# Altium schematic sheet ESP32.SchDoc(ESP32)
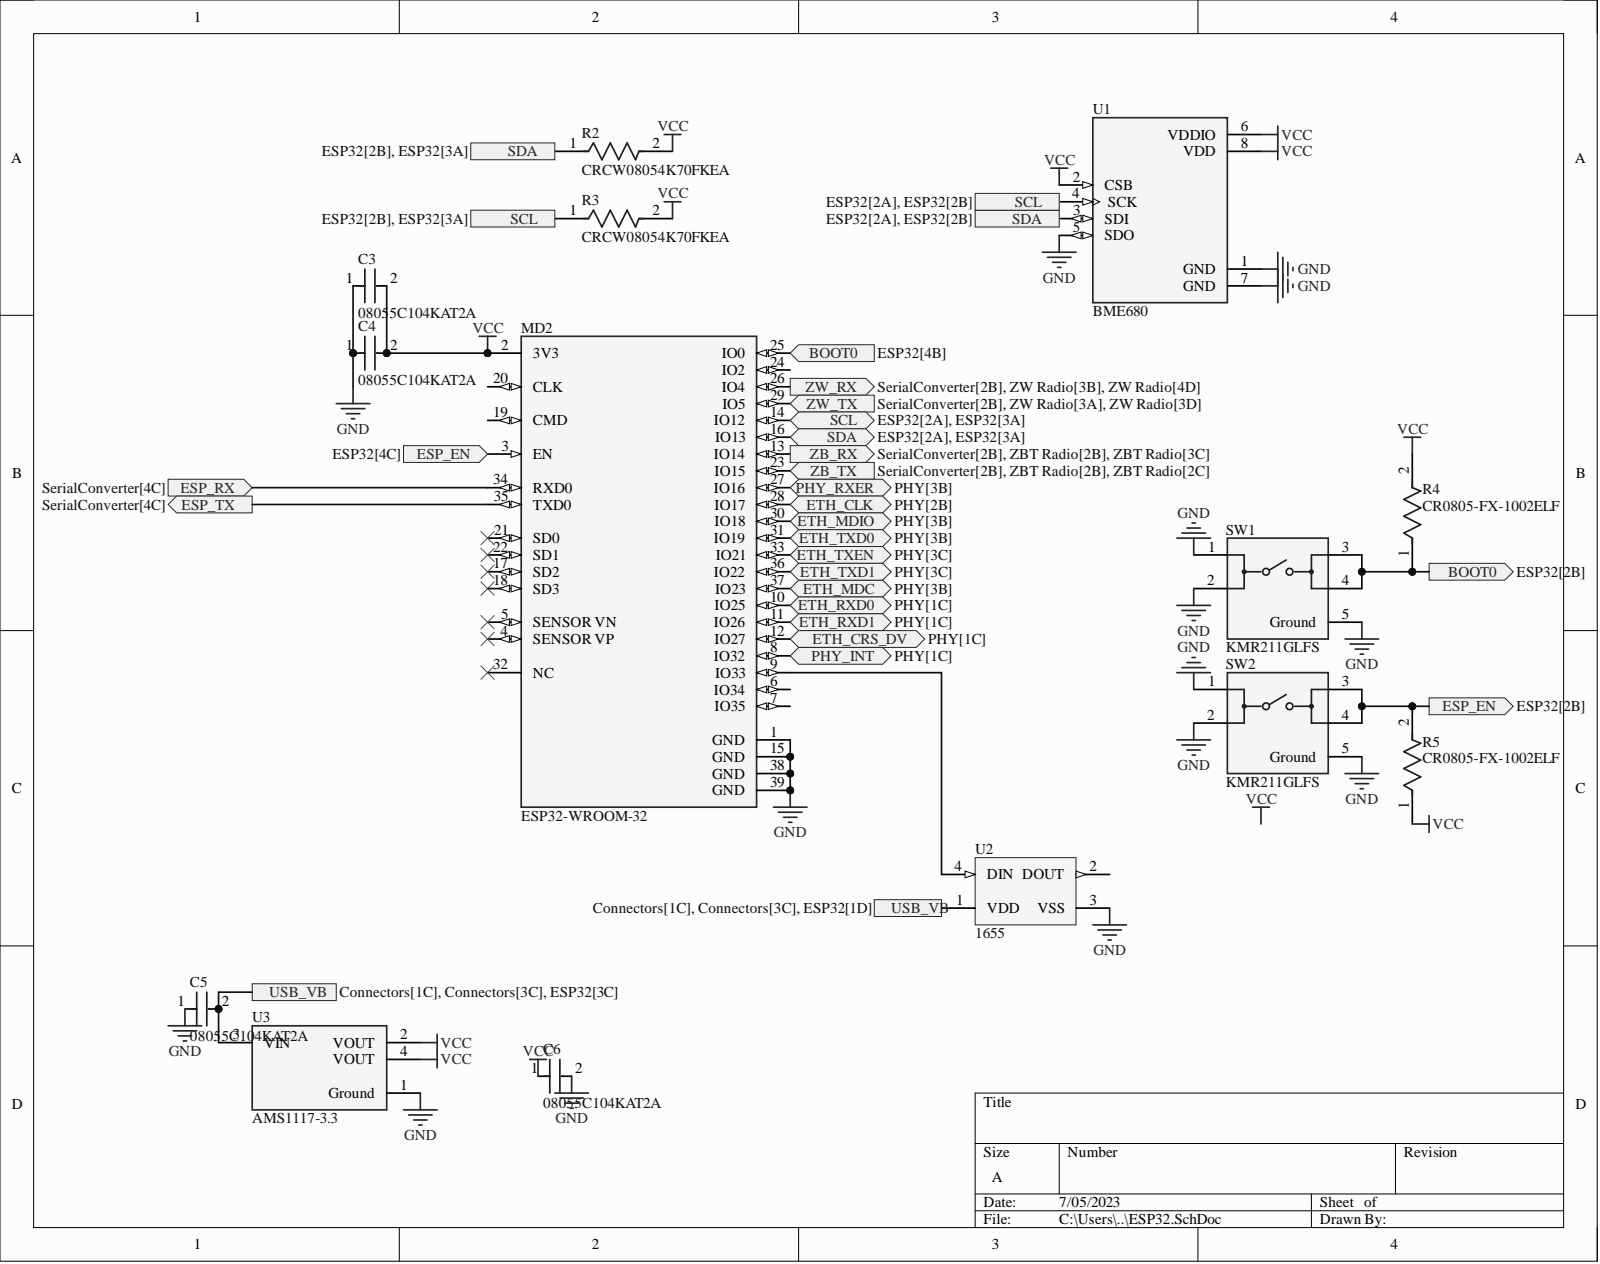
[diagram p0: ESP32.SchDoc]

## Parts
C3  08055C104KAT2A  [FP-0805-L_2_01_0_2-W_1_25-IPC_C]
C4  08055C104KAT2A  [FP-0805-L_2_01_0_2-W_1_25-IPC_C]
C5  08055C104KAT2A  [FP-0805-L_2_01_0_2-W_1_25-IPC_C]
C6  08055C104KAT2A  [FP-0805-L_2_01_0_2-W_1_25-IPC_C]
MD2  ESP32-WROOM-32  [FP-ESP32-WROOM-32-MFG]
R2  CRCW08054K70FKEA  [FP-CRCW0805-e3-IPC_B]
R3  CRCW08054K70FKEA  [FP-CRCW0805-e3-IPC_B]
R4  CR0805-FX-1002ELF  [FP-CR0805-IPC_A]
R5  CR0805-FX-1002ELF  [FP-CR0805-IPC_A]
SW1  KMR211GLFS  [FP-KMR211GLFS-MFG]
SW2  KMR211GLFS  [FP-KMR211GLFS-MFG]
U1  BME680  [PSON80P300X300X100-8N]
U2  1655  [ADA-RGB-1655_V]
U3  AMS1117-3.3  [FP-AMS1117-IPC_C]

## Ports
BOOT0, ESP_EN, ESP_RX, ESP_TX, ETH_CLK, ETH_CRS_DV, ETH_MDC, ETH_MDIO, ETH_RXD0, ETH_RXD1, ETH_TXD0, ETH_TXD1, ETH_TXEN, PHY_INT, PHY_RXER, SCL, SDA, USB_VB, ZB_RX, ZB_TX, ZW_RX, ZW_TX

## Nets
GND: C3.1 C4.1 C5.1 C6.2 MD2.1(GND) MD2.15(GND) MD2.38(GND) MD2.39(GND) SW1.1 SW1.2 SW1.5(Ground) SW2.1 SW2.2 SW2.5(Ground) U1.1(GND) U1.5(SDO) U1.7(GND) U2.3(VSS) U3.1(Ground)
VCC: C3.2 C4.2 C6.1 MD2.2(3V3) R2.2 R3.2 R4.2 R5.1 U1.2(CSB) U1.6(VDDIO) U1.8(VDD) U3.2(VOUT) U3.4(VOUT)
BOOT0: MD2.25(IO0) R4.1 SW1.3 SW1.4 ; port BOOT0
ESP_EN: MD2.3(EN) R5.2 SW2.3 SW2.4 ; port ESP_EN
ESP_RX: MD2.34(RXD0) ; port ESP_RX
ESP_TX: MD2.35(TXD0) ; port ESP_TX
ETH_CLK: MD2.28(IO17) ; port ETH_CLK
ETH_CRS_DV: MD2.12(IO27) ; port ETH_CRS_DV
ETH_MDC: MD2.37(IO23) ; port ETH_MDC
ETH_MDIO: MD2.30(IO18) ; port ETH_MDIO
ETH_RXD0: MD2.10(IO25) ; port ETH_RXD0
ETH_RXD1: MD2.11(IO26) ; port ETH_RXD1
ETH_TXD0: MD2.31(IO19) ; port ETH_TXD0
ETH_TXD1: MD2.36(IO22) ; port ETH_TXD1
ETH_TXEN: MD2.33(IO21) ; port ETH_TXEN
PHY_INT: MD2.8(IO32) ; port PHY_INT
PHY_RXER: MD2.27(IO16) ; port PHY_RXER
SCL: MD2.14(IO12) R3.1 U1.4(SCK) ; port SCL
SDA: MD2.16(IO13) R2.1 U1.3(SDI) ; port SDA
USB_VB: C5.2 U2.1(VDD) U3.3(VIN) ; port USB_VB
ZB_RX: MD2.13(IO14) ; port ZB_RX
ZB_TX: MD2.23(IO15) ; port ZB_TX
ZW_RX: MD2.26(IO4) ; port ZW_RX
ZW_TX: MD2.29(IO5) ; port ZW_TX
NetMD2_9: MD2.9(IO33) U2.4(DIN)

Not connected: MD2 4(SENSOR_VP) 5(SENSOR_VN) 6(IO34) 7(IO35) 17(SD2) 18(SD3) 19(CMD) 20(CLK) 21(SD0) 22(SD1) 24(IO2) 32(NC); U2 2(DOUT)

## Library data of the parts
C3-C6 = CMP-2000-05016-2: General Purpose Ceramic Capacitor, 0805, 100nF, 10%, X7R, 0.15, 50V; Dissipation Factor: 2.5%; Insulation Resistance: 100GR; Capacitance: 100nF; Case Code (Imperial): 0805; Case Code (Metric): 2012; Case/Package: 0805; Component Kind: Standard; Depth: 1.25mm; Dielectric: X7R; Dielectric Material: Ceramic; Height: 0.5mm; Lead Free: Lead Free; Length: 2mm; Library Name: Altium Content Vault; Max Operating Temperature: 125°C; Min Operating Temperature: -55°C; Mount: Surface Mount; Number of Pins: 2; Package Quantity: 4000; Packaging: Cut Tape; Radiation Hardening: No; REACH SVHC: No SVHC; RoHS Compliant: Yes; Series: MLCC X7R; Termination: Standard; Thickness: 0.037inch; Tolerance: 10%; Voltage: 50V; Voltage Rating: 50V; Voltage Rating (DC): 50V; Weight: 0.001411oz; Width: 1.2mm
MD2 = CMP-194065-000008-1: RX TXRX MOD WIFI SURFACE MOUNT; Data Rate: 150Mbps; Frequency: 2.5GHz; Operating Supply Voltage: 3.6V; Sensitivity: -98dBm; Case/Package: SMD/SMT; Component Kind: Standard; Library Name: Altium Content Vault; Max Operating Temperature: 85°C; Min Operating Temperature: -40°C; Mount: Surface Mount; Package Quantity: 550; Packaging: Cut Tape; Weight: 0.088185oz
R2 R3 = CMP-2100-03615-2: RES Thick Film, 4.7kΩ, 1%, 0.125W, 100ppm/°C, 0805; Max Power Dissipation: 125mW; Resistance: 4.7kR; Voltage Rating: 150V; Case Code (Imperial): 0805; Case Code (Metric): 2012; Case/Package: 0805; Component Kind: Standard; Composition: Thick Film; Contact Plating: Tin; Depth: 1.25mm; Features: Automotive AEC-Q200; Height: 0.45mm; Lead Free: Lead Free; Length: 2mm; Library Name: Altium Content Vault; Max Operating Temperature: 155°C; Military Standard: Not; Min Operating Temperature: -55°C; Number of Terminations: 2; Package Quantity: 10; Packaging: Tape and Reel; Power Rating: 125mW; Radiation Hardening: No; Temperature Coefficient: 100ppm/°C; Termination: SMD/SMT; Tolerance: 1%; Voltage Rating (DC): 150V; Weight: 0.000194oz; Width: 1.25mm
R4 R5 = CMP-07231-003204-1: Thick Film Chip Resistors 0805 10kΩ 0.125W 1% 100ppm/°C; Max Power Dissipation: 125mW; Resistance: 10kR; Voltage Rating: 150V; Voltage Rating (DC): 100V; Case Code (Imperial): 0805; Case Code (Metric): 2012; Case/Package: 0805; Component Kind: Standard; Composition: Thick Film; Contact Plating: Tin; Depth: 1.25mm; Features: Moisture Resistant; Height: 0.5mm; Length: 2mm; Library Name: Altium Content Vault; Max Operating Temperature: 155°C; Min Operating Temperature: -55°C; Mount: Surface Mount; Number of Terminations: 2; Package Quantity: 1; Packaging: Tape and Reel; Power Rating: 125mW; Radiation Hardening: No; REACH SVHC: No SVHC; Temperature Coefficient: 100ppm/°C; Termination: SMD/SMT; Thickness: 508um; Tolerance: 1%; Width: 1.25mm
SW1 SW2 = CMP-10040-000023-1: SWITCH TACTILE SPST-NO 0.05A 32V; Actuator Length: 0.5mm; Actuator Type: Standard; Contact Current Rating: 50mA; Illumination Type: Non-Illuminated; Mechanical Life: 200000Cycles; Operating Force: 1.2N; Power Rating: 1W; Switch Function: Off-Mom; Switch Travel: 0.2mm; Throw Configuration: SPST; Component Kind: Standard; Contact Material: Silver; Contact Plating: Silver; Current Rating: 50mA; Depth: 4.6mm; Lead Free: Lead Free; Length: 2.8mm; Library Name: Altium Content Vault; Max Current Rating: 50mA; Max Operating Temperature: 85°C; Max Voltage Rating (DC): 32V; Min Operating Temperature: -40°C; Mount: Surface Mount; Orientation: Straight; Package Quantity: 1; Packaging: Tape and Reel; Radiation Hardening: No; REACH SVHC: No SVHC; Termination: Gull Wing; Voltage Rating (DC): 32V; Width: 2.8mm
U1 = BME680: Integrated Environmental Unit; MF: {'manufacturer': u'Bosch Sensortec'}; Availability: Unavailable; Component Kind: Standard; MP: BME680; Package: None; Price: None
U2 = CMP-2000-05026-1: Intelligent Control LED Integrated Light Source, 3.5 to 5.3 V, -25 to 80 degC, 4-Pin SMD, RoHS, Tape and Reel; Manufacturer: Adafruit-Industries-LLC; Configuration: Discrete; Number of LEDs: 10; Utilized LED: WS2812(5050); Category: Optoelectronics; Color: Red,Green,Blue(RGB); Component Kind: Standard; Controller Type: -; Datasheet URL: http://datasheet.octopart.com/ADA1655-Adafruit-Industries-datasheet-32028139.pdf; Datasheet Version: May-13; Family: Addressable,Specialty; Interface: -; Library Name: Altium Content Vault; Manufacturer URL: https://www.adafruit.com/; Mounting Type: SurfaceMount; Package Description: 4-Pin Surface Mount Device, Body 5 x 5 mm; Package Reference: 1655; Series: Neopixel; Size / Dimension: 5.00mmLx5.00mmW
U3 = CMP-209535-000001-1: LDO Voltage Regulators 1A, 3.3V; Manufacturer: Advanced Monolithic Systems; Dropout Voltage: 1.1V; Max Junction Temp: +125°C; Max Power Dissipation: 1.2W; Max Supply Voltage: 15V; Nominal Supply Current: 5mA; Number Of Outputs: 1; Output Type: Fixed; Standoff Height: 0.64mm; Automotive: No; Category: IC; Component Kind: Standard; ComponentLink2Description: Datasheet; ComponentLink2URL: http://www.advanced-monolithic.com/pdf/ds1117.pdf; Device Class L1: Integrated Circuits (ICs); Device Class L2: Power Management ICs; Device Class L3: Voltage Regulators - Linear; Height: 1.8mm; Ipc Land Pattern Name: SOT229P700X180-4; Lead Free: Yes; Library Name: Altium Content Vault; Manufacturer Part Number: AMS1117-3.3; Octopart: https://octopart.com/ams1117-3.3-advanced+monolithic+systems-25899300; Output Current: 1A; Output Voltage: 3.3V; Package: AMS1117; RoHS: TRUE; Temperature: -40°C to +125°C; Temperature Range High: +125°C; Temperature Range Low: -40°C
